# Altium schematic sheet sync_sampling.SchDoc(sync_sampling)
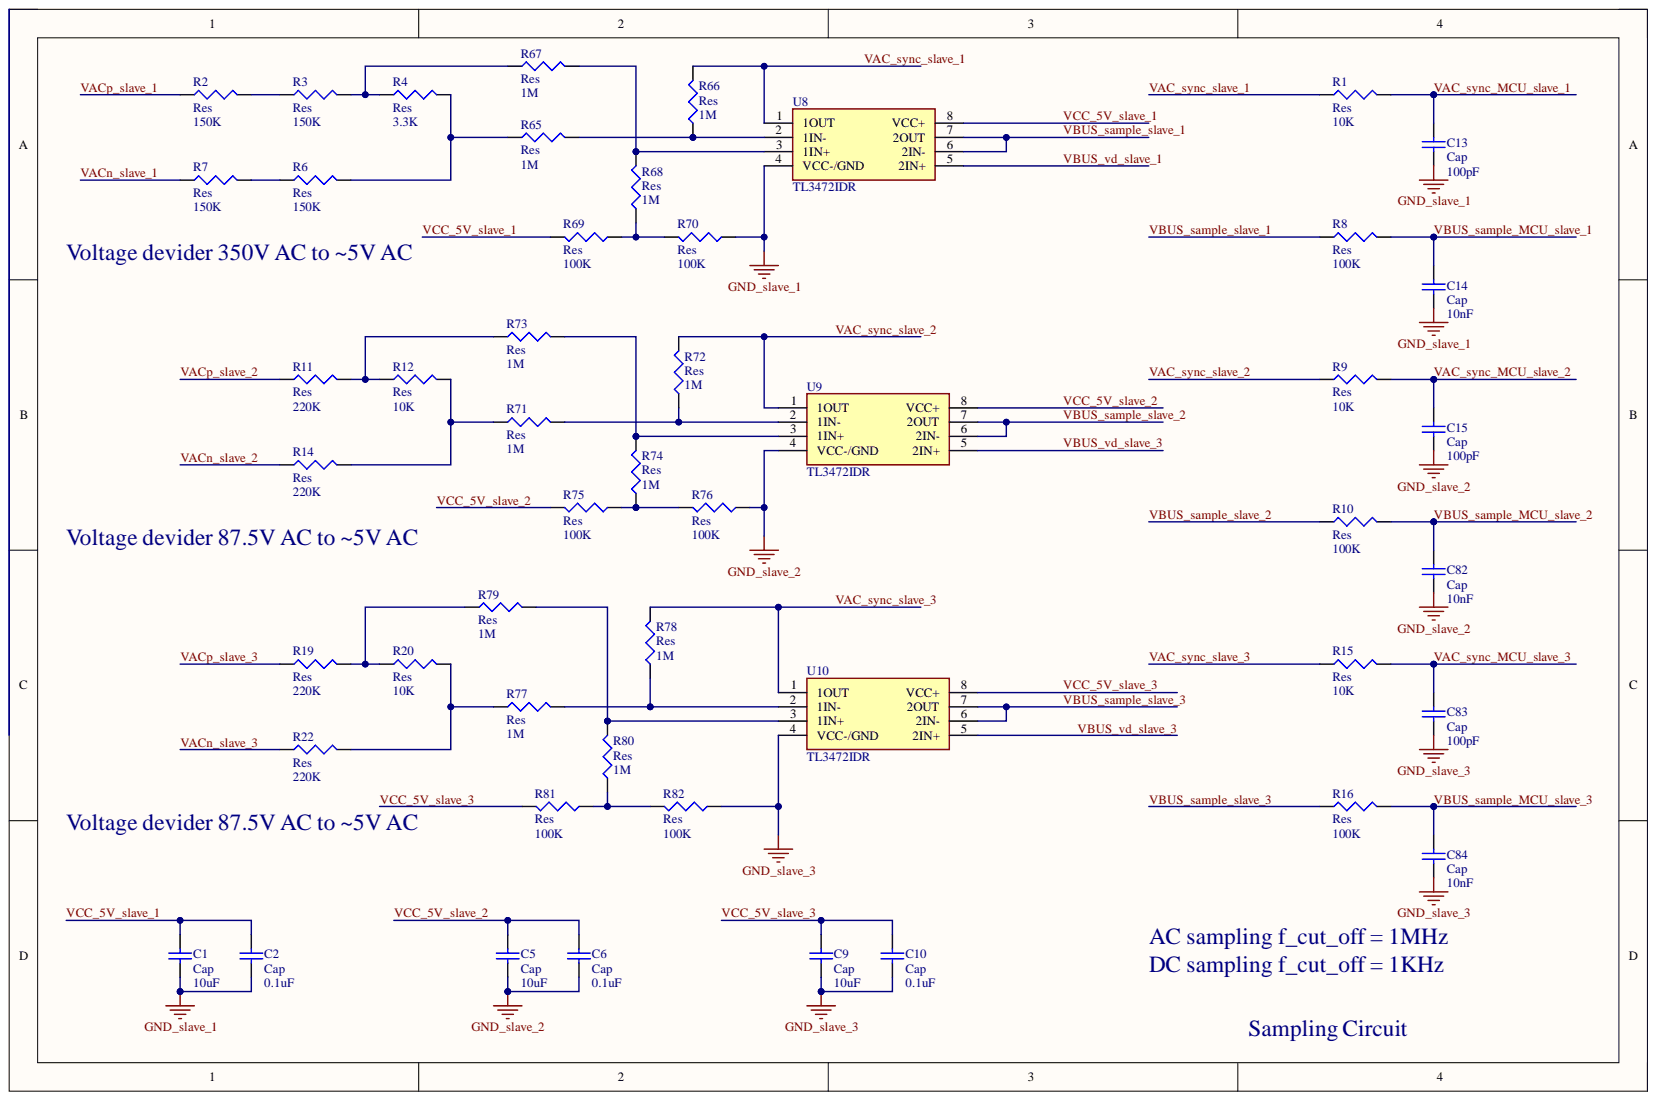
[diagram p0: sync_sampling.SchDoc]

## Parts
C1  Cap  value 10uF  [C0805]
C2  Cap  value 0.1uF  [C0805]
C5  Cap  value 10uF  [C0805]
C6  Cap  value 0.1uF  [C0805]
C9  Cap  value 10uF  [C0805]
C10  Cap  value 0.1uF  [C0805]
C13  Cap  value 100pF  [C0805]
C14  Cap  value 10nF  [C0805]
C15  Cap  value 100pF  [C0805]
C82  Cap  value 10nF  [C0805]
C83  Cap  value 100pF  [C0805]
C84  Cap  value 10nF  [C0805]
R1  Res  value 10K  [RES0805W]
R2  Res  value 150K  [RES0805W]
R3  Res  value 150K  [RES0805W]
R4  Res  value 3.3K  [RES0805W]
R6  Res  value 150K  [RES0805W]
R7  Res  value 150K  [RES0805W]
R8  Res  value 100K  [RES0805W]
R9  Res  value 10K  [RES0805W]
R10  Res  value 100K  [RES0805W]
R11  Res  value 220K  [RES0805W]
R12  Res  value 10K  [RES0805W]
R14  Res  value 220K  [RES0805W]
R15  Res  value 10K  [RES0805W]
R16  Res  value 100K  [RES0805W]
R19  Res  value 220K  [RES0805W]
R20  Res  value 10K  [RES0805W]
R22  Res  value 220K  [RES0805W]
R65  Res  value 1M  [RES0805W]
R66  Res  value 1M  [RES0805W]
R67  Res  value 1M  [RES0805W]
R68  Res  value 1M  [RES0805W]
R69  Res  value 100K  [RES0805W]
R70  Res  value 100K  [RES0805W]
R71  Res  value 1M  [RES0805W]
R72  Res  value 1M  [RES0805W]
R73  Res  value 1M  [RES0805W]
R74  Res  value 1M  [RES0805W]
R75  Res  value 100K  [RES0805W]
R76  Res  value 100K  [RES0805W]
R77  Res  value 1M  [RES0805W]
R78  Res  value 1M  [RES0805W]
R79  Res  value 1M  [RES0805W]
R80  Res  value 1M  [RES0805W]
R81  Res  value 100K  [RES0805W]
R82  Res  value 100K  [RES0805W]
U8  TL3472IDR  [SOIC127P600X175-8N]
U9  TL3472IDR  [SOIC127P600X175-8N]
U10  TL3472IDR  [SOIC127P600X175-8N]

## Nets
GND_slave_1: C1.1 C2.1 C13.1 C14.1 R70.1 U8.4(VCC-/GND)
GND_slave_2: C5.1 C6.1 C15.1 C82.1 R76.1 U9.4(VCC-/GND)
GND_slave_3: C9.1 C10.1 C83.1 C84.1 R82.1 U10.4(VCC-/GND)
VAC_sync_MCU_slave_1: C13.2 R1.2
VAC_sync_MCU_slave_2: C15.2 R9.2
VAC_sync_MCU_slave_3: C83.2 R15.2
VAC_sync_slave_1: R1.1 R66.2 U8.1(1OUT)
VAC_sync_slave_2: R9.1 R72.2 U9.1(1OUT)
VAC_sync_slave_3: R15.1 R78.2 U10.1(1OUT)
VACn_slave_1: R7.1
VACn_slave_2: R14.1
VACn_slave_3: R22.1
VACp_slave_1: R2.1
VACp_slave_2: R11.1
VACp_slave_3: R19.1
VBUS_sample_MCU_slave_1: C14.2 R8.2
VBUS_sample_MCU_slave_2: C82.2 R10.2
VBUS_sample_MCU_slave_3: C84.2 R16.2
VBUS_sample_slave_1: R8.1 U8.6(2IN-) U8.7(2OUT)
VBUS_sample_slave_2: R10.1 U9.6(2IN-) U9.7(2OUT)
VBUS_sample_slave_3: R16.1 U10.6(2IN-) U10.7(2OUT)
VBUS_vd_slave_1: U8.5(2IN+)
VBUS_vd_slave_3: U9.5(2IN+) U10.5(2IN+)
VCC_5V_slave_1: C1.2 C2.2 R69.2 U8.8(VCC+)
VCC_5V_slave_2: C5.2 C6.2 R75.2 U9.8(VCC+)
VCC_5V_slave_3: C9.2 C10.2 R81.2 U10.8(VCC+)
NetR2_2: R2.2 R3.1
NetR3_2: R3.2 R4.1 R67.1
NetR4_2: R4.2 R6.2 R65.1
NetR6_1: R6.1 R7.2
NetR11_2: R11.2 R12.1 R73.1
NetR12_2: R12.2 R14.2 R71.1
NetR19_2: R19.2 R20.1 R79.1
NetR20_2: R20.2 R22.2 R77.1
NetR65_2: R65.2 R66.1 U8.2(1IN-)
NetR67_2: R67.2 R68.2 U8.3(1IN+)
NetR68_1: R68.1 R69.1 R70.2
NetR71_2: R71.2 R72.1 U9.2(1IN-)
NetR73_2: R73.2 R74.2 U9.3(1IN+)
NetR74_1: R74.1 R75.1 R76.2
NetR77_2: R77.2 R78.1 U10.2(1IN-)
NetR79_2: R79.2 R80.2 U10.3(1IN+)
NetR80_1: R80.1 R81.1 R82.2

## Library data of the parts
C1 C2 C5 C6 C9 C10 C13-C15 C82-C84 = Cap: Capacitor; Component Kind: Standard; LatestRevisionDate: 17-Jul-2002; LatestRevisionNote: Re-released for DXP Platform.; Library Name: Miscellaneous Devices.IntLib; PackageDescription: Radial Cap, Thru-Hole; 2 Leads; 0.3 in Pin Spacing; PackageReference: RAD-0.3; Published: 8-Jun-2000; Signal Integrity: Cap; Simulation: CAP; Publisher: Altium Limited
R1-R4 R6-R12 R14-R16 R19 R20 R22 R65-R82 = Res3: Resistor; Component Kind: Standard; LatestRevisionDate: 29-May-2009; LatestRevisionNote: IPC-7351 Footprint Added.; Library Name: Miscellaneous Devices.IntLib; PackageDescription: Chip Resistor; PackageReference: J1-0603; Published: 8-Jun-2000; Signal Integrity: Res; Simulation: RESISTOR; Publisher: Altium Limited
U8-U10 = TL3472IDR: Integrated Circuit; Manufacturer_Name: Texas Instruments; Component Kind: Standard; Datasheet Link: http://www.ti.com/lit/gpn/tl3472; Height: 1.75mm; Library Name: TL3472IDR.SchLib; Manufacturer_Part_Number: TL3472IDR
